# Altium schematic sheet 05pwr_input.SchDoc
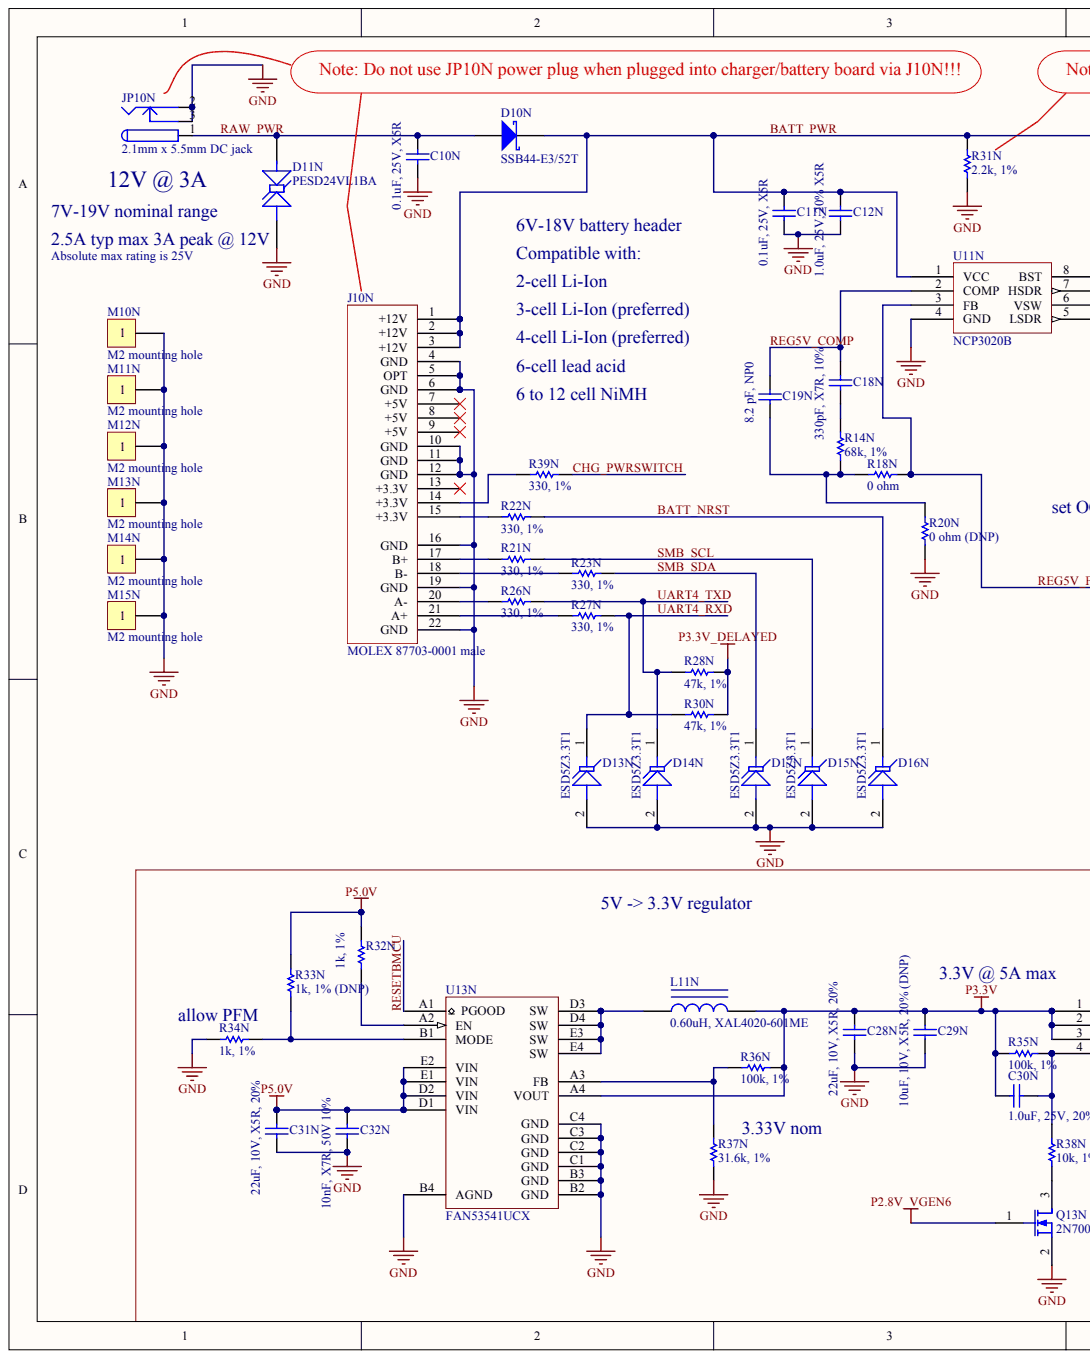
[diagram p0: 05pwr_input.SchDoc, left]
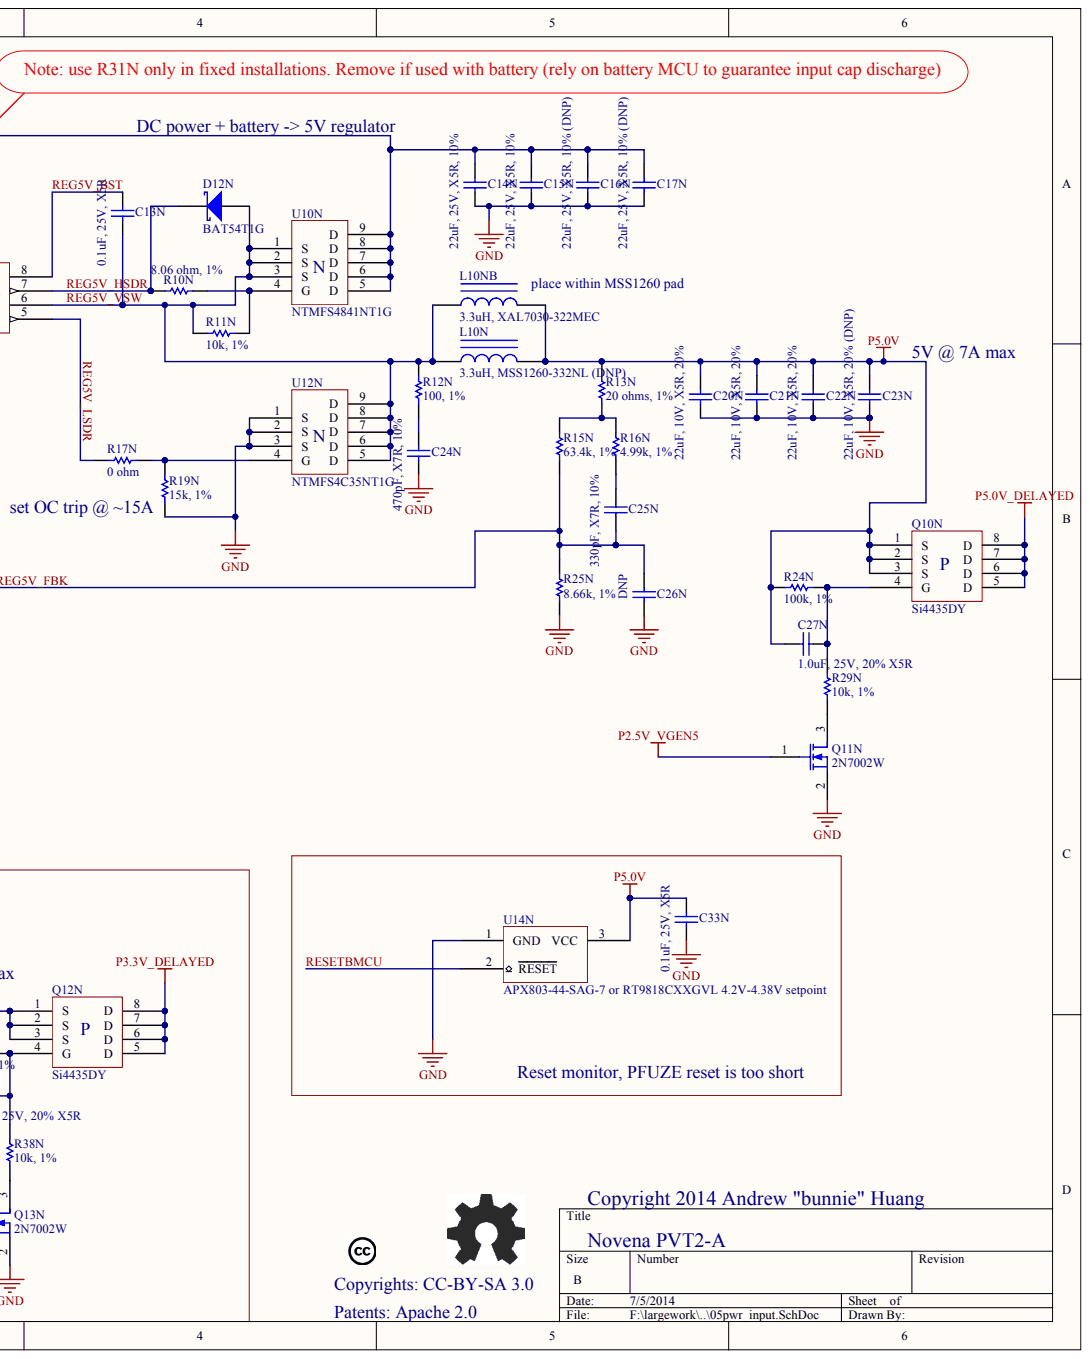
[diagram p1: 05pwr_input.SchDoc, right]

## Parts
C10N  0.1uF, 25V, X5R
C11N  0.1uF, 25V, X5R
C12N  1.0uF, 25V, 20% X5R
C13N  0.1uF, 25V, X5R
C14N  22uF, 25V, X5R, 10%
C15N  22uF, 25V, X5R, 10%
C16N  22uF, 25V, X5R, 10% (DNP)
C17N  22uF, 25V, X5R, 10% (DNP)
C18N  330pF, X7R, 10%
C19N  8.2 pF, NP0
C20N  22uF, 10V, X5R, 20%
C21N  22uF, 10V, X5R, 20%
C22N  22uF, 10V, X5R, 20%
C23N  22uF, 10V, X5R, 20% (DNP)
C24N  470pF, X7R, 10%
C25N  330pF, X7R, 10%
C26N  DNP
C27N  1.0uF, 25V, 20% X5R
C28N  22uF, 10V, X5R, 20%
C29N  10uF, 10V, X5R, 20% (DNP)
C30N  1.0uF, 25V, 20% X5R
C31N  22uF, 10V, X5R, 20%
C32N  10nF, X7R, 50V 10%
C33N  0.1uF, 25V, X5R
D10N  SSB44-E3/52T
D11N  PESD24VL1BA
D12N  BAT54T1G
D13N  ESD5Z3.3T1
D14N  ESD5Z3.3T1
D15N  ESD5Z3.3T1
D16N  ESD5Z3.3T1
D17N  ESD5Z3.3T1
J10N  MOLEX 87703-0001 male
JP10N  2.1mm x 5.5mm DC jack
L10N  3.3uH, MSS1260-332NL (DNP)
L10NB  3.3uH, XAL7030-322MEC
L11N  0.60uH, XAL4020-601ME
M10N  M2 mounting hole
M11N  M2 mounting hole
M12N  M2 mounting hole
M13N  M2 mounting hole
M14N  M2 mounting hole
M15N  M2 mounting hole
Q10N  Si4435DY
Q11N  2N7002W
Q12N  Si4435DY
Q13N  2N7002W
R10N  8.06 ohm, 1%
R11N  10k, 1%
R12N  100, 1%
R13N  20 ohms, 1%
R14N  68k, 1%
R15N  63.4k, 1%
R16N  4.99k, 1%
R17N  0 ohm
R18N  0 ohm
R19N  15k, 1%
R20N  0 ohm (DNP)
R21N  330, 1%
R22N  330, 1%
R23N  330, 1%
R24N  100k, 1%
R25N  8.66k, 1%
R26N  330, 1%
R27N  330, 1%
R28N  47k, 1%
R29N  10k, 1%
R30N  47k, 1%
R31N  2.2k, 1%
R32N  1k, 1%
R33N  1k, 1% (DNP)
R34N  1k, 1%
R35N  100k, 1%
R36N  100k, 1%
R37N  31.6k, 1%
R38N  10k, 1%
R39N  330, 1%
U10N  NTMFS4841NT1G
U11N  NCP3020B
U12N  NTMFS4C35NT1G
U13N  FAN53541UCX
U14N  APX803-44-SAG-7 or RT9818CXXGVL 4.2V-4.38V setpoint

## Nets
GND: C10N.2 C11N.2 C12N.2 C14N.2 C15N.2 C16N.2 C17N.2 C20N.2 C21N.2 C22N.2 C23N.2 C24N.2 C26N.2 C28N.2 C29N.2 C31N.2 C32N.2 C33N.2 D11N.1 D13N.2 D14N.2 D15N.2 D16N.2 D17N.2 J10N.4(GND) J10N.5(OPT) J10N.6(GND) J10N.10(GND) J10N.11(GND) J10N.12(GND) J10N.16(GND) J10N.19(GND) J10N.22(GND) JP10N.2 JP10N.3 M10N.1 M11N.1 M12N.1 M13N.1 M14N.1 M15N.1 Q11N.2 Q13N.2 R19N.1 R20N.1 R25N.1 R31N.1 R34N.2 R37N.1 U11N.4(GND) U12N.1(S) U12N.2(S) U12N.3(S) U13N.B2(GND) U13N.B3(GND) U13N.B4(AGND) U13N.C1(GND) U13N.C2(GND) U13N.C3(GND) U13N.C4(GND) U14N.1
P2.5V_VGEN5: Q11N.1
P2.8V_VGEN6: Q13N.1
P3.3V: C28N.1 C29N.1 C30N.1 L11N.2 Q12N.1(S) Q12N.2(S) Q12N.3(S) R35N.2 R36N.1 U13N.A4(VOUT)
P3.3V_DELAYED: Q12N.5(D) Q12N.6(D) Q12N.7(D) Q12N.8(D) R28N.1 R30N.1
P5.0V: C20N.1 C21N.1 C22N.1 C23N.1 C27N.1 C31N.1 C32N.1 C33N.1 L10N.2 L10NB.2 Q10N.1(S) Q10N.2(S) Q10N.3(S) R13N.2 R24N.2 R32N.1 R33N.2 U13N.D1(VIN) U13N.D2(VIN) U13N.E1(VIN) U13N.E2(VIN) U14N.3
P5.0V_DELAYED: Q10N.5(D) Q10N.6(D) Q10N.7(D) Q10N.8(D)
BATT_NRST: D16N.1 R22N.2
BATT_PWR: C11N.1 C12N.1 C14N.1 C15N.1 C16N.1 C17N.1 D10N.2 J10N.1(+12V) J10N.2(+12V) J10N.3(+12V) R31N.2 U10N.5(D) U10N.6(D) U10N.7(D) U10N.8(D) U10N.9(D) U11N.1(VCC)
CHG_PWRSWITCH: R39N.2
RAW_PWR: C10N.1 D10N.1 D11N.2 JP10N.1
REG5V_BST: C13N.1 U11N.8(BST)
REG5V_COMP: C18N.1 C19N.1 U11N.2(COMP)
REG5V_FBK: C25N.2 C26N.1 R15N.1 R18N.2 R25N.2 U11N.3(FB)
REG5V_HSDR: D12N.2 R10N.1 U11N.7(HSDR)
REG5V_LSDR: R17N.1 U11N.5(LSDR)
REG5V_VSW: C13N.2 D12N.1 L10N.1 L10NB.1 R11N.2 R12N.2 U10N.1(S) U10N.2(S) U10N.3(S) U11N.6(VSW) U12N.5(D) U12N.6(D) U12N.7(D) U12N.8(D) U12N.9(D)
RESETBMCU: U13N.A1(PGOOD) U14N.2
SMB_SCL: D15N.1 R21N.1
SMB_SDA: D17N.1 R23N.2
UART4_RXD: D13N.1 R27N.2 R30N.2
UART4_TXD: D14N.1 R26N.2 R28N.2
NetC18N_2: C18N.2 R14N.2
NetC19N_2: C19N.2 R14N.1 R18N.1 R20N.2
NetC24N_1: C24N.1 R12N.1
NetC25N_1: C25N.1 R16N.1
NetC27N_2: C27N.2 Q10N.4(G) R24N.1 R29N.1
NetC30N_2: C30N.2 Q12N.4(G) R35N.1 R38N.1
NetJ10N_14: J10N.14(+3.3V) R39N.1
NetJ10N_15: J10N.15(+3.3V) R22N.1
NetJ10N_17: J10N.17(B+) R21N.2
NetJ10N_18: J10N.18(B-) R23N.1
NetJ10N_20: J10N.20(A-) R26N.1
NetJ10N_21: J10N.21(A+) R27N.1
NetL11N_1: L11N.1 U13N.D3(SW) U13N.D4(SW) U13N.E3(SW) U13N.E4(SW)
NetQ11N_3: Q11N.3 R29N.2
NetQ13N_3: Q13N.3 R38N.2
NetR10N_2: R10N.2 R11N.1 U10N.4(G)
NetR13N_1: R13N.1 R15N.2 R16N.2
NetR17N_2: R17N.2 R19N.2 U12N.4(G)
NetR32N_2: R32N.2 U13N.A2(EN)
NetR33N_1: R33N.1 R34N.1 U13N.B1(MODE)
NetR36N_2: R36N.2 R37N.2 U13N.A3(FB)

Not connected: J10N 7(+5V) 8(+5V) 9(+5V) 13(+3.3V)

## Library data of the parts
D10N D12N = (no symbol name): Code - JEDEC: DO-214-AA; Published: 8-Jun-2000; Revision: July-2002: Re-released for DXP Platform.; Publisher: Altium Limited
R10N R11N R12N R13N R14N R15N R16N R17N R18N R19N R20N R21N R22N R23N R25N R26N R27N R28N R29N R30N R31N R32N R33N R34N R37N R38N R39N = (no symbol name): Value: 100k; Published: 8-Jun-2000; LatestRevisionDate: 17-Jul-2002; LatestRevisionNote: Re-released for DXP Platform.; PackageReference: AXIAL-0.3; Publisher: Altium Limited
C10N C11N C12N C13N C14N C15N C16N C17N C18N C19N C20N C21N C22N C23N C24N C25N C26N C27N C28N C29N C30N C31N C32N C33N D11N D13N D14N D15N D16N D17N J10N JP10N L10N L10NB L11N M10N M11N M12N M13N M14N M15N Q10N Q11N Q12N Q13N R24N R35N R36N U10N U11N U12N U13N U14N = (no symbol name)
  C10N C11N C12N C13N C14N C15N C16N C17N C18N C19N C20N C21N C22N C23N C24N C25N C26N C27N C28N C29N C30N C31N C32N C33N: Published: 8-Jun-2000; LatestRevisionDate: 17-Jul-2002; LatestRevisionNote: Re-released for DXP Platform.; PackageReference: C1206
  D13N D14N D15N D16N D17N: Published: 24-Mar-1999; LatestRevisionDate: 17-Jul-2002; LatestRevisionNote: Re-released for DXP Platform.; PackageReference: DO-7
  JP10N: Published: 17-Dec-2003; Datasheet: Latest Revision: 09-May-2002
  L10N L10NB L11N: Published: 24-Mar-1999; LatestRevisionDate: 17-Jul-2002; LatestRevisionNote: Re-released for DXP Platform.; PackageReference: AXIAL-0.9
  Q11N Q13N: Published: 8-Jun-2000; LatestRevisionDate: 17-Jul-2002; LatestRevisionNote: Re-released for DXP Platform.; PackageVersion: 1995/1996; PackageReference: E3
  R24N R35N R36N: Published: 8-Jun-2000; LatestRevisionDate: 17-Jul-2002; LatestRevisionNote: Re-released for DXP Platform.; PackageReference: AXIAL-0.3; Value: 100k
  C10N C11N C12N C13N C14N C15N C16N C17N C18N C19N C20N C21N C22N C23N C24N C25N C26N C27N C28N C29N C30N C31N C32N C33N D13N D14N D15N D16N D17N JP10N L10N L10NB L11N Q11N Q13N R24N R35N R36N: Publisher: Altium Limited
